# Altium schematic sheet [13] DAC.SchDoc("[13] DAC")
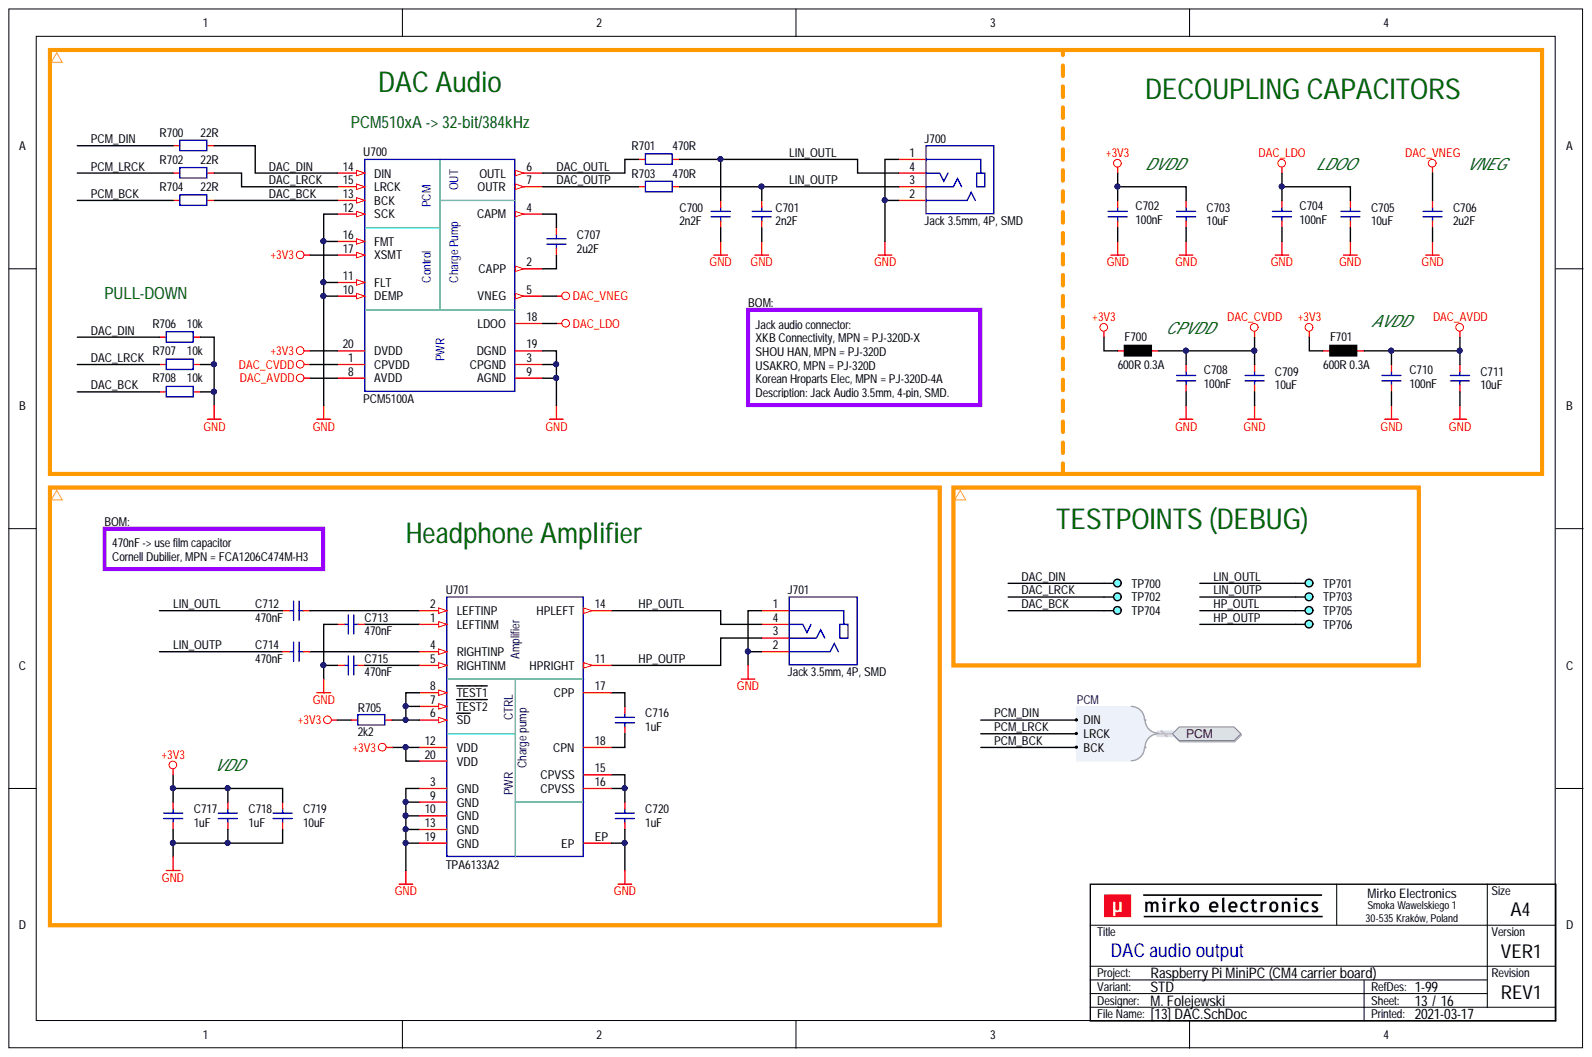
[diagram p0: [13] DAC.SchDoc]

## Parts
C700  2n2F  [CAP_0603_R]
C701  2n2F  [CAP_0603_R]
C702  100nF  [CAP_0603_R]
C703  10uF  [CAP_0603_R]
C704  100nF  [CAP_0603_R]
C705  10uF  [CAP_0603_R]
C706  2u2F  [CAP_0603_R]
C707  2u2F  [CAP_0603_R]
C708  100nF  [CAP_0603_R]
C709  10uF  [CAP_0603_R]
C710  100nF  [CAP_0603_R]
C711  10uF  [CAP_0603_R]
C712  470nF  [CAP_1206_R]
C713  470nF  [CAP_1206_R]
C714  470nF  [CAP_1206_R]
C715  470nF  [CAP_1206_R]
C716  1uF  [CAP_0603_R]
C717  1uF  [CAP_0603_R]
C718  1uF  [CAP_0603_R]
C719  10uF  [CAP_0603_R]
C720  1uF  [CAP_0603_R]
F700  600R 0.3A  [FER_0603_R]
F701  600R 0.3A  [FER_0603_R]
J700  Jack 3.5mm, 4P, SMD  [CON_XKB_PJ-320D]
J701  Jack 3.5mm, 4P, SMD  [CON_XKB_PJ-320D]
R700  22R  [RES_0603_R]
R701  470R  [RES_0603_R]
R702  22R  [RES_0603_R]
R703  470R  [RES_0603_R]
R704  22R  [RES_0603_R]
R705  2k2  [RES_0603_R]
R706  10k  [RES_0603_R]
R707  10k  [RES_0603_R]
R708  10k  [RES_0603_R]
TP700  DEBUG  [PCB_TP_DBG_50]  (value not drawn)  (no BOM)
TP701  DEBUG  [PCB_TP_DBG_50]  (value not drawn)  (no BOM)
TP702  DEBUG  [PCB_TP_DBG_50]  (value not drawn)  (no BOM)
TP703  DEBUG  [PCB_TP_DBG_50]  (value not drawn)  (no BOM)
TP704  DEBUG  [PCB_TP_DBG_50]  (value not drawn)  (no BOM)
TP705  DEBUG  [PCB_TP_DBG_50]  (value not drawn)  (no BOM)
TP706  DEBUG  [PCB_TP_DBG_50]  (value not drawn)  (no BOM)
U700  PCM5100A  [ICC_TSSOP20]
U701  TPA6133A2

## Ports
PCM, PCM.BCK, PCM.DIN, PCM.LRCK

## Nets
+3V3: C702.2 C703.2 C717.2 C718.2 C719.2 F700.1 F701.1 R705.1 U700.17(XSMT) U700.20(DVDD) U701.12(VDD) U701.20(VDD)
DAC_AVDD: C710.2 C711.2 F701.2 U700.8(AVDD)
DAC_CVDD: C708.2 C709.2 F700.2 U700.1(CPVDD)
DAC_LDO: C704.2 C705.2 U700.18(LDOO)
DAC_VNEG: C706.2 U700.5(VNEG)
GND: C700.1 C701.1 C702.1 C703.1 C704.1 C705.1 C706.1 C708.1 C709.1 C710.1 C711.1 C713.2 C715.2 C717.1 C718.1 C719.1 C720.1 J700.1 J700.2 J701.1 J701.2 R706.1 R707.1 R708.1 U700.3(CPGND) U700.9(AGND) U700.10(DEMP) U700.11(FLT) U700.12(SCK) U700.16(FMT) U700.19(DGND) U701.3(GND) U701.9(GND) U701.10(GND) U701.13(GND) U701.19(GND) U701.EP
DAC_BCK: R704.2 R708.2 TP704.1 U700.13(BCK)
DAC_DIN: R700.2 R706.2 TP700.1 U700.14(DIN)
DAC_LRCK: R702.2 R707.2 TP702.1 U700.15(LRCK)
DAC_OUTL: R701.1 U700.6(OUTL)
DAC_OUTP: R703.1 U700.7(OUTR)
HP_OUTL: J701.4 TP705.1 U701.14(HPLEFT)
HP_OUTP: J701.3 TP706.1 U701.11(HPRIGHT)
LIN_OUTL: C700.2 C712.1 J700.4 R701.2 TP701.1
LIN_OUTP: C701.2 C714.1 J700.3 R703.2 TP703.1
PCM_BCK: R704.1 ; port PCM
PCM_DIN: R700.1 ; port PCM
PCM_LRCK: R702.1 ; port PCM
NetC707_1: C707.1 U700.2(CAPP)
NetC707_2: C707.2 U700.4(CAPM)
NetC712_2: C712.2 U701.2(LEFTINP)
NetC713_1: C713.1 U701.1(LEFTINM)
NetC714_2: C714.2 U701.4(RIGHTINP)
NetC715_1: C715.1 U701.5(RIGHTINM)
NetC716_1: C716.1 U701.18(CPN)
NetC716_2: C716.2 U701.17(CPP)
NetC720_2: C720.2 U701.15(CPVSS) U701.16(CPVSS)
NetR705_2: R705.2 U701.6(SD) U701.7(TEST2) U701.8(TEST1)

## Library data of the parts
C700 C701 C703 C705-C707 C709 C711 C716-C720 = CAP-0023: Capacitor; MLCC; GENERIC; TBD; TBD; TBD; TBD; SMD; 0603 (1608);; Database Table Name: CAPACITOR$; Voltage Rating: TBD; Tolerance: TBD; ROHS Status: TBD; Packaging Type: TBD; Package (Case): 0603 (1608); Operating Temperature: TBD; MPN: GENERIC; Mounting Type: SMD; Manufacturer: GENERIC; ID: CAP-0023; Features: TBD; Dielectric: TBD; Component Type: Capacitor; Component Subtype: MLCC; Component Kind: Standard; Component Height: TBD; Component Body: TBD; Capacitance: GENERIC; Library Name: MirFol_db_local.DbLib
  C700 C701: Value: 2n2F
  C703 C705 C709 C711 C719: Value: 10uF
  C706 C707: Value: 2u2F
  C716-C718 C720: Value: 1uF
C702 C704 C708 C710 = CAP-0008: Capacitor; MLCC; 100nF; TBD; TBD; TBD; TBD; SMD; 0603 (1608);; Database Table Name: CAPACITOR$; Voltage Rating: TBD; Value: 100nF; Tolerance: TBD; ROHS Status: TBD; Packaging Type: TBD; Package (Case): 0603 (1608); Operating Temperature: TBD; MPN: TBD; Mounting Type: SMD; Manufacturer: TBD; ID: CAP-0008; Features: TBD; Dielectric: TBD; Component Type: Capacitor; Component Subtype: MLCC; Component Kind: Standard; Component Height: TBD; Component Body: TBD; Capacitance: 100nF; Library Name: MirFol_db_local.DbLib
C712-C715 = CAP-0025: Capacitor; MLCC; GENERIC; TBD; TBD; TBD; TBD; SMD; 1206 (3216);; Database Table Name: CAPACITOR$; Voltage Rating: TBD; Value: 470nF; Tolerance: TBD; ROHS Status: TBD; Packaging Type: TBD; Package (Case): 1206 (3216); Operating Temperature: TBD; MPN: GENERIC; Mounting Type: SMD; Manufacturer: GENERIC; ID: CAP-0025; Features: TBD; Dielectric: TBD; Component Type: Capacitor; Component Subtype: MLCC; Component Kind: Standard; Component Height: TBD; Component Body: TBD; Capacitance: GENERIC; Library Name: MirFol_db_local.DbLib
F700 F701 = IND_FB: Inductor; Ferrite Bead;; Value: 600R 0.3A; Component Kind: Standard; Library Name: MirFol_lib.SchLib
J700 J701 = CON_AUDIO_JACK_4P: Connector; Audio Jack; 4-pin;; MPN: PJ-320D-X; Manufacturer: XKB Connectivity; Value: Jack 3.5mm, 4P, SMD; Component Kind: Standard; Library Name: MirFol_lib.SchLib
R700 R702 R704 = RES-0030: Resistor; Thick Film; 22R; 1%; 100mW; 100PPM; 75V; Standard; SMD; 0603 (1608);; MPN: TBD; Manufacturer: Yageo Corporation; Packaging Type: Tape & Reel; Database Table Name: RESISTOR$; Component Body: 1.60 x 0.80mm; Voltage Rating: 75V; Value: 22R; Tolerance: 1%; TCR: 100PPM; ROHS Status: RoHS Compliant; Resistance: 22R; Power Rating: 100mW; Package (Case): 0603 (1608); Operating Temperature: -55°C to +155°C; Mounting Type: SMD; ID: RES-0030; Features: Standard; Component Type: Resistor; Component Subtype: Thick Film; Component Kind: Standard; Component Height: 0.45mm; Library Name: MirFol_db_local.DbLib
R701 R703 = RES-0042: Resistor; Thick Film; 470R; 1%; 100mW; 100PPM; 75V; Standard; SMD; 0603 (1608);; MPN: TBD; Manufacturer: Yageo Corporation; Packaging Type: Tape & Reel; Database Table Name: RESISTOR$; Component Body: 1.60 x 0.80mm; Voltage Rating: 75V; Value: 470R; Tolerance: 1%; TCR: 100PPM; ROHS Status: RoHS Compliant; Resistance: 470R; Power Rating: 100mW; Package (Case): 0603 (1608); Operating Temperature: -55°C to +155°C; Mounting Type: SMD; ID: RES-0042; Features: Standard; Component Type: Resistor; Component Subtype: Thick Film; Component Kind: Standard; Component Height: 0.45mm; Library Name: MirFol_db_local.DbLib
R705 = RES-0033: Resistor; Thick Film; 2k2; 1%; 100mW; 100PPM; 75V; Standard; SMD; 0603 (1608);; MPN: TBD; Manufacturer: Yageo Corporation; Packaging Type: Tape & Reel; Database Table Name: RESISTOR$; Component Body: 1.60 x 0.80mm; Voltage Rating: 75V; Value: 2k2; Tolerance: 1%; TCR: 100PPM; ROHS Status: RoHS Compliant; Resistance: 2k2; Power Rating: 100mW; Package (Case): 0603 (1608); Operating Temperature: -55°C to +155°C; Mounting Type: SMD; ID: RES-0033; Features: Standard; Component Type: Resistor; Component Subtype: Thick Film; Component Kind: Standard; Component Height: 0.45mm; Library Name: MirFol_db_local.DbLib
R706-R708 = RES-0028: Resistor; Thick Film; 10k; 1%; 100mW; 100PPM; 75V; Standard; SMD; 0603 (1608);; MPN: RC0603FR-0710KL; Manufacturer: Yageo Corporation; Packaging Type: Tape & Reel; Database Table Name: RESISTOR$; Component Body: 1.60 x 0.80mm; Voltage Rating: 75V; Value: 10k; Tolerance: 1%; TCR: 100PPM; ROHS Status: RoHS Compliant; Resistance: 10k; Power Rating: 100mW; Package (Case): 0603 (1608); Operating Temperature: -55°C to +155°C; Mounting Type: SMD; ID: RES-0028; Features: Standard; Component Type: Resistor; Component Subtype: Thick Film; Component Kind: Standard; Component Height: 0.45mm; Library Name: MirFol_db_local.DbLib
TP700-TP706 = PCB_TP_DBG: PCB Marking; Test Point (Debug);; Value: DEBUG; Library Name: MirFol_lib.SchLib
U700 = ICC_TXI_PCM510x_TSSOP: IC; Audio DAC;; MPN: PCM5100APW; Manufacturer: Texas Instruments; Value: PCM5100A; Component Kind: Standard; Library Name: MirFol_lib.SchLib
U701 = ICC_TXI_TPA6133A2_WQFN20: IC; Headphone Amplifier;; MPN: TPA6133A2RTJT; Manufacturer: Texas Instruments; Value: TPA6133A2; Component Kind: Standard; Library Name: MirFol_lib.SchLib
